# Altium schematic sheet DW1000BreakoutPeripherals.SchDoc(DW1000BreakoutPeripherals)
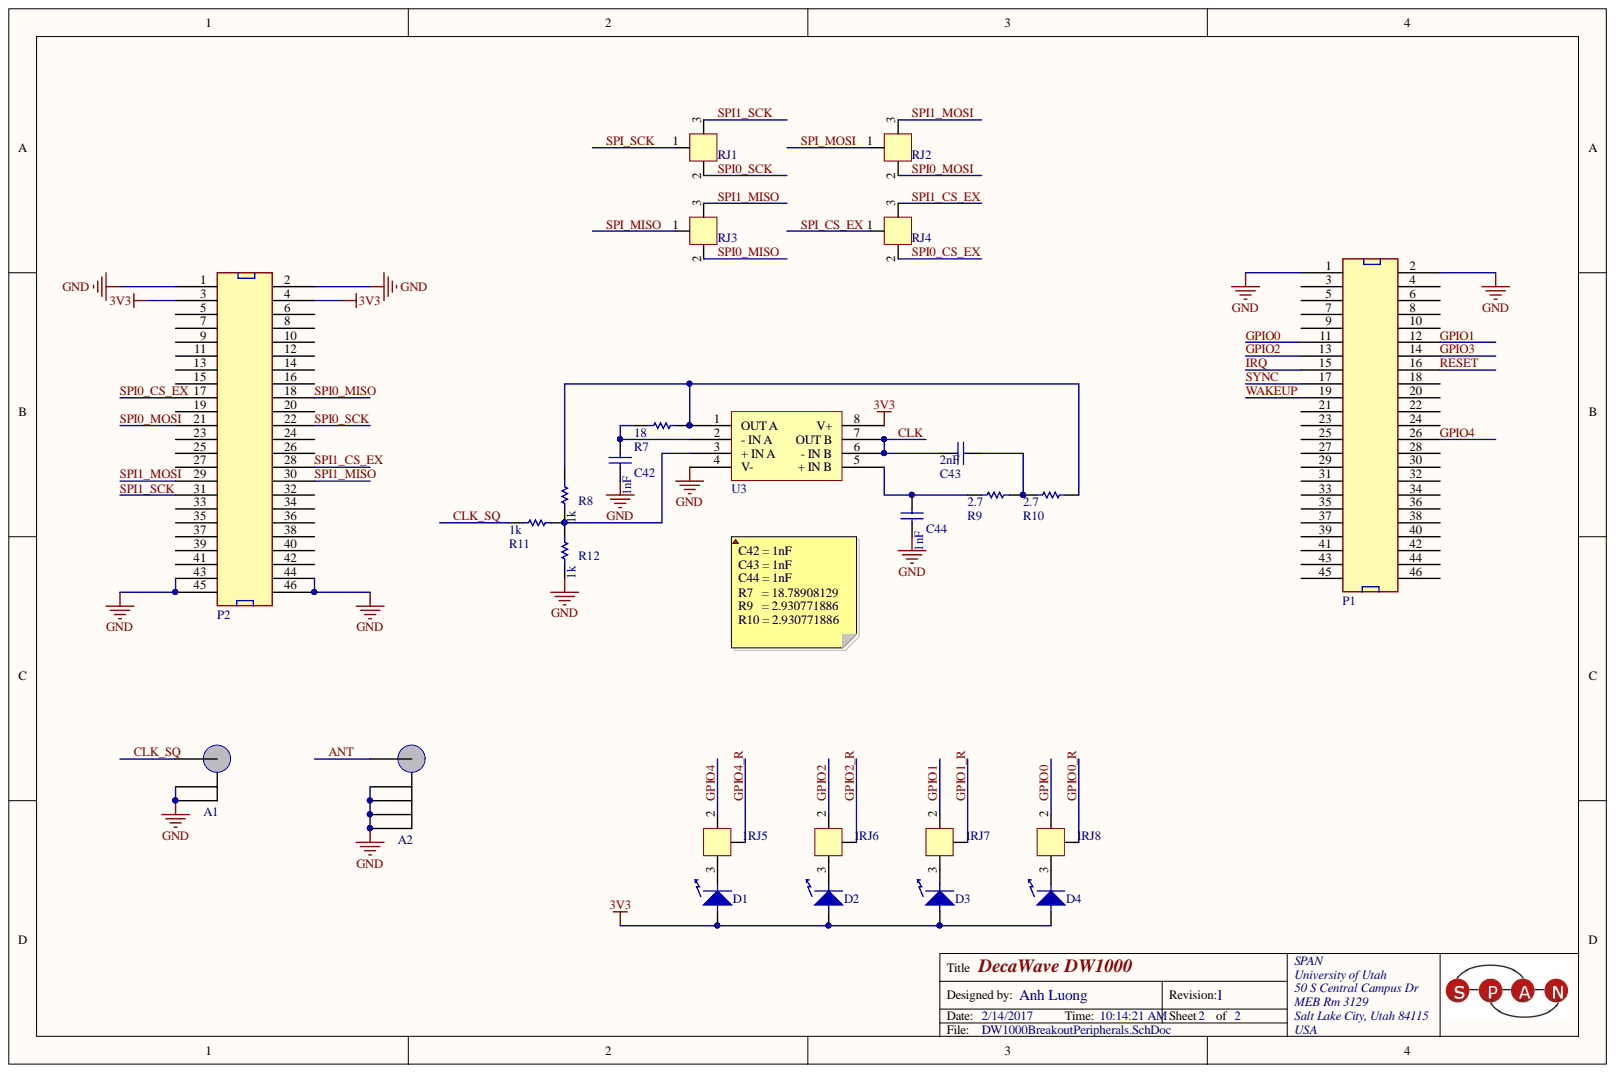
[diagram p0: DW1000BreakoutPeripherals.SchDoc]

## Parts
A1  U.FL-R-SMT(01)  [U.FL-R-SMT-1]  (value not drawn)
A2  CONSMA003.062  [SMA_EDGE_MNT]  (value not drawn)
C42  GRM033R71C102KA01D  [CAPC1608X08N (0603)]  (value not drawn)
C43  GRM1885C1H202JA01D  [CAPC1608X08N (0603)]  (value not drawn)
C44  GRM033R71C102KA01D  [CAPC1608X08N (0603)]  (value not drawn)
D1  LG Q971-KN-1  [CHIPLED0603]  (value not drawn)
D2  LG Q971-KN-1  [CHIPLED0603]  (value not drawn)
D3  LG Q971-KN-1  [CHIPLED0603]  (value not drawn)
D4  LG Q971-KN-1  [CHIPLED0603]  (value not drawn)
P1  PREC023DAAN-RC  [HEADER23X2]  (value not drawn)
P2  PREC023DAAN-RC  [HEADER23X2]  (value not drawn)
R7  MCR03ERTJ180  [RESC1608X06N (0603)]  (value not drawn)
R8  Resistance (Ohms): 1k  [RESC1608X06N (0603)]  (hidden comment: MCR03ERTJ102)
R9  Resistance (Ohms): 2.7  [RESC1608X06N (0603)]  (hidden comment: MCR03ERTJ2R7)
R10  Resistance (Ohms): 2.7  [RESC1608X06N (0603)]  (hidden comment: MCR03ERTJ2R7)
R11  Resistance (Ohms): 1k  [RESC1608X06N (0603)]  (hidden comment: MCR03ERTJ102)
R12  Resistance (Ohms): 1k  [RESC1608X06N (0603)]  (hidden comment: MCR03ERTJ102)
RJ1  R_J  [RJ]
RJ2  R_J  [RJ]
RJ3  R_J  [RJ]
RJ4  R_J  [RJ]
RJ5  R_J  [RJ]
RJ6  R_J  [RJ]
RJ7  R_J  [RJ]
RJ8  R_J  [RJ]
U3  LMV822MM/NOPB  [TSOP65P490X110-8N]  (value not drawn)

## Nets
3V3: D1.1 D2.1 D3.1 D4.1 P2.3 P2.4 U3.8(V+)
GND: A1.2 A1.3 A2.2 A2.3 A2.4 A2.5 C42.1 C44.1 P1.1 P1.2 P2.1 P2.2 P2.43 P2.44 P2.45 P2.46 R12.1 U3.4(V-)
ANT: A2.1
CLK: C43.1 U3.6(-_IN_B) U3.7(OUT_B)
CLK_SQ: A1.1 R11.1
GPIO0: P1.11 RJ8.2
GPIO0_R: RJ8.1
GPIO1: P1.12 RJ7.2
GPIO1_R: RJ7.1
GPIO2: P1.13 RJ6.2
GPIO2_R: RJ6.1
GPIO3: P1.14
GPIO4: P1.26 RJ5.2
GPIO4_R: RJ5.1
IRQ: P1.15
RESET: P1.16
SPI0_CS_EX: P2.17 RJ4.2
SPI0_MISO: P2.18 RJ3.2
SPI0_MOSI: P2.21 RJ2.2
SPI0_SCK: P2.22 RJ1.2
SPI1_CS_EX: P2.28 RJ4.3
SPI1_MISO: P2.30 RJ3.3
SPI1_MOSI: P2.29 RJ2.3
SPI1_SCK: P2.31 RJ1.3
SPI_CS_EX: RJ4.1
SPI_MISO: RJ3.1
SPI_MOSI: RJ2.1
SPI_SCK: RJ1.1
SYNC: P1.17
WAKEUP: P1.19
NetC42_2: C42.2 R7.1 U3.2(-_IN_A)
NetC43_2: C43.2 R9.2 R10.1
NetC44_2: C44.2 R9.1 U3.5(+_IN_B)
NetD1_2: D1.2 RJ5.3
NetD2_2: D2.2 RJ6.3
NetD3_2: D3.2 RJ7.3
NetD4_2: D4.2 RJ8.3
NetR7_2: R7.2 R8.2 R10.2 U3.1(OUT_A)
NetR8_1: R8.1 R11.2 R12.2 U3.3(+_IN_A)

Not connected: P1 3 4 5 6 7 8 9 10 18 20 21 22 23 24 25 27 28 29 30 31 32 33 34 35 36 37 38 39 40 41 42 43 44 45 46; P2 5 6 7 8 9 10 11 12 13 14 15 16 19 20 23 24 25 26 27 32 33 34 35 36 37 38 39 40 41 42

## Library data of the parts
A1 = U.FL-R-SMT(01): CONN UMC JACK STR 50 OHM SMD; Manufacturer: Hirose Electric Co Ltd; Shield Termination: Solder; Impedance: 50 Ohm; Frequency - Max: 6GHz; Dielectric Material: Liquid Crystal Polymer (LCP); Connector Type: Jack, Male Pin; Connector Style: Ultra Miniature Coaxial; Center Contact Plating: Gold; Center Contact Material: Phosphor Bronze; Body Finish: Silver; Voltage Rating: -; Supplier 1: Digi-Key; Stock 1: 22286; RoHS: RoHS Compliant; Pricing 1: 1=1.28, 10=1.122, 25=1.056, 50=1.012, 100=0.968, 250=0.88, 500=0.792, 1000=0.704, 2500=0.638 (USD); Packaging: Bulk; Operating Temperature: -40°C ~ 90°C; Mounting Type: Surface Mount; Manufacturer Part Number: U.FL-R-SMT(01); Library Name: DW1000Breakout.SchLib; Ingress Protection: -; Housing Color: Silver; Features: -; Fastening Type: Snap-On; Contact Termination: Solder; ComponentLink1URL: http://www.hirose.co.jp/cataloge_hp/e32119372.pdf; ComponentLink1Description: http://www.hirose.co.jp/cataloge_hp/e32119372.pdf; Component Kind: Standard; Category: Connectors, Interconnects; Cable Group: -; Body Material: Phosphor Bronze
A2 = CONSMA003.062: CONN SMA JACK 50 OHM EDGE MNT; Manufacturer: Linx Technologies Inc; Shield Termination: Solder; Impedance: 50 Ohm; Dielectric Material: Polytetrafluoroethylene (PTFE); Connector Type: Jack, Female Socket; Center Contact Plating: Gold; Center Contact Material: Beryllium Copper; Body Material: Brass; Body Finish: Nickel; Voltage Rating: -; Supplier 1: Digi-Key; Stock 1: 6857; RoHS: RoHS Compliant; Pricing 1: 1=3.37, 25=3.09, 100=2.81, 500=2.6125 (USD); Packaging: Bulk; Operating Temperature: -65°C ~ 165°C; Mounting Type: Board Edge, End Launch; Manufacturer Part Number: CONSMA003.062; Library Name: DW1000Breakout.SchLib; Ingress Protection: -; Housing Color: Silver; Frequency - Max: -; Features: -; Fastening Type: Threaded; Contact Termination: Solder; Connector Style: SMA; ComponentLink1URL: http://www.linxtechnologies.com/resources/diagrams/consma003.062.pdf; ComponentLink1Description: http://www.linxtechnologies.com/resources/diagrams/consma003.062.pdf; Component Kind: Standard; Category: Connectors, Interconnects; Cable Group: -
C42 C44 = GRM033R71C102KA01D: CAP CER 1000PF 16V 10% X7R 0201; Manufacturer: Murata Electronics North America; Voltage - Rated: 16V; Tolerance: ±10%; Thickness (Max): 0.013" (0.33mm); Temperature Coefficient: X7R; Supplier 1: Digi-Key; Stock 1: 938390; Size / Dimension: 0.024" L x 0.012" W (0.60mm x 0.30mm); RoHS: RoHS Compliant; Ratings: -; Pricing 1: 1=0.1, 10=0.025, 50=0.0134, 100=0.0113, 250=0.00924, 500=0.0079, 1000=0.00615, 5000=0.00513 (USD); Packaging: Cut Tape (CT); Package / Case: 0201 (0603 Metric); Operating Temperature: -55°C ~ 125°C; Mounting Type: Surface Mount, MLCC; Manufacturer Part Number: GRM033R71C102KA01D; Library Name: DW1000Breakout.SchLib; Lead Style: -; Lead Spacing: -; Height - Seated (Max): -; Features: -; ComponentLink1URL: http://search.murata.co.jp/Ceramy/image/img/A01X/G101/ENG/GRM033R71C102KA01-01.pdf; ComponentLink1Description: http://search.murata.co.jp/Ceramy/image/img/A01X/G101/ENG/GRM033R71C102KA01-01.pdf; Component Kind: Standard; Category: Capacitors; Capacitance: 1000pF; Applications: General Purpose
C43 = GRM1885C1H202JA01D: CAP CER 2000PF 50V NP0 0603; Manufacturer: Murata Electronics North America; Tolerance: ±5%; Temperature Coefficient: C0G, NP0; Voltage - Rated: 50V; Thickness (Max): 0.035" (0.90mm); Supplier Part Number 1: 490-1458-1-ND; Supplier 1: Digi-Key; Stock 1: 163868; Size / Dimension: 0.063" L x 0.031" W (1.60mm x 0.80mm); RoHS: RoHS Compliant; Ratings: -; Pricing 1: 1=0.1, 10=0.073, 50=0.0394, 100=0.0333, 250=0.02728, 500=0.02334, 1000=0.01818 (USD); Part Status: Active; Packaging: Cut Tape (CT); Package / Case: 0603 (1608 Metric); Operating Temperature: -55°C ~ 125°C; Mounting Type: Surface Mount, MLCC; Manufacturer Part Number: GRM1885C1H202JA01D; Library Name: DW1000Breakout.SchLib; Lead Style: -; Lead Spacing: -; Height - Seated (Max): -; Features: -; ComponentLink1URL: http://www.murata.com/~/media/webrenewal/support/library/catalog/products/capacitor/mlcc/c02e.ashx; ComponentLink1Description: http://www.murata.com/~/media/webrenewal/support/library/catalog/products/capacitor/mlcc/c02e.ashx; Component Kind: Standard; Category: Capacitors; Capacitance: 2000pF; Applications: General Purpose
D1-D4 = LG Q971-KN-1: LED CHIPLED 570NM GREEN 0603 SMD; Manufacturer: OSRAM Opto Semiconductors Inc; Wavelength - Peak: 572nm; Voltage - Forward (Vf) Typ: 2.2V; Viewing Angle: 160°; Millicandela Rating: 10mcd; Lens Type: Diffused; Lens Style/Size: Rectangle with Flat Top, 1mm x 0.8mm; Current - Test: 20mA; Wavelength - Dominant: 570nm; Supplier 1: Digi-Key; Stock 1: 44000; Size / Dimension: 1.60mm L x 0.80mm W; RoHS: RoHS Compliant; Pricing 1: 4000=0.03632 (USD); Packaging: Tape & Reel (TR); Package / Case: 0603 (1608 Metric); Mounting Type: Surface Mount; Manufacturer Part Number: LG Q971-KN-1; Luminous Flux @ Current - Test: -; Height: 0.80mm; ComponentLink1URL: http://catalog.osram-os.com/catalogue/catalogue.do;jsessionid=DE4D071D0D2758CC117C711BF848053E?act=downloadFile&favOid=0200000600005069000100b6; ComponentLink1Description: http://catalog.osram-os.com/catalogue/catalogue.do;jsessionid=DE4D071D0D2758CC117C711BF848053E?act=downloadFile&favOid=0200000600005069000100b6; Component Kind: Standard; Color: Green; Category: Optoelectronics; Library Name: DWM1000Breakout.SchLib
P1 P2 = PREC023DAAN-RC: CONN HEADER .100" DUAL STR 46POS; Manufacturer: Sullins Connector Solutions; Row Spacing: 0.100" (2.54mm); Number of Positions Loaded: All; Number of Positions: 46; Connector Type: Header, Unshrouded; Termination: Solder; Supplier 1: Digi-Key; Stock 1: 0; RoHS: RoHS Compliant; Pricing 1: 1=0.79, 10=0.706, 100=0.5813, 500=0.45952, 1000=0.39447, 5000=0.35987, 10000=0.34603 (USD); Pitch: 0.100" (2.54mm); Packaging: Bulk; Number of Rows: 2; Mounting Type: Through Hole; Manufacturer Part Number: PREC023DAAN-RC; Features: -; Fastening Type: -; Contact Type: Male Pin; Contact Mating Length: 0.230" (5.84mm); Contact Finish Thickness: -; Contact Finish: Tin; ComponentLink1URL: http://media.digikey.com/PDF/Data%20Sheets/Sullins%20PDFs/xRxCzzzDxxN-RC_11636-B.pdf; ComponentLink1Description: http://media.digikey.com/PDF/Data%20Sheets/Sullins%20PDFs/xRxCzzzDxxN-RC_11636-B.pdf; Component Kind: Standard; Color: Black; Category: Connectors, Interconnects; Library Name: Chronos.SchLib
R7 = MCR03ERTJ180: RES SMD 18 OHM 5% 1/10W 0603; Manufacturer: Rohm Semiconductor; Temperature Coefficient: ±200ppm/°C; Resistance (Ohms): 18; Power (Watts): 0.1W, 1/10W; Tolerance: ±5%; Supplier Part Number 1: RHM18CGCT-ND; Supplier Device Package: 0603; Supplier 1: Digi-Key; Stock 1: 8252; Size / Dimension: 0.063" L x 0.031" W (1.60mm x 0.80mm); RoHS: RoHS Compliant; Pricing 1: 1=0.1, 10=0.017, 25=0.012, 50=0.0092, 100=0.0068, 250=0.00524, 500=0.00418, 1000=0.00307 (USD); Part Status: Active; Packaging: Cut Tape (CT); Package / Case: 0603 (1608 Metric); Operating Temperature: -55°C ~ 155°C; Number of Terminations: 2; Manufacturer Part Number: MCR03ERTJ180; Library Name: DW1000Breakout.SchLib; Height - Seated (Max): 0.022" (0.55mm); Features: -; Failure Rate: -; Composition: Thick Film; ComponentLink1URL: http://rohmfs.rohm.com/en/products/databook/datasheet/passive/resistor/chip_resistor/mcr_g.pdf; ComponentLink1Description: http://rohmfs.rohm.com/en/products/databook/datasheet/passive/resistor/chip_resistor/mcr_g.pdf; Component Kind: Standard; Category: Resistors
R8 R11 R12 = MCR03ERTJ102: RES 1K OHM 1/10W 5% 0603 SMD; Manufacturer: Rohm Semiconductor; Temperature Coefficient: ±200ppm/°C; Power (Watts): 0.1W, 1/10W; Tolerance: ±5%; Supplier Device Package: 0603 (1608 Metric); Supplier 1: Digi-Key; Stock 1: 72962; Size / Dimension: 0.063" L x 0.031" W (1.60mm x 0.80mm); RoHS: RoHS Compliant; Pricing 1: 1=0.1, 10=0.01, 25=0.0072, 100=0.0042, 250=0.00316, 500=0.00254, 1000=0.00187 (USD); Packaging: Cut Tape (CT); Package / Case: 0603 (1608 Metric); Operating Temperature: -55°C ~ 155°C; Number of Terminations: 2; Manufacturer Part Number: MCR03ERTJ102; Library Name: DW1000Breakout.SchLib; Height: 0.022" (0.55mm); Features: -; Composition: Thick Film; ComponentLink1URL: http://rohmfs.rohm.com/en/products/databook/datasheet/passive/resistor/chip_resistor/mcr_g.pdf; ComponentLink1Description: http://rohmfs.rohm.com/en/products/databook/datasheet/passive/resistor/chip_resistor/mcr_g.pdf; Component Kind: Standard; Category: Resistors
R9 R10 = MCR03ERTJ2R7: RES SMD 2.7 OHM 5% 1/10W 0603; Manufacturer: Rohm Semiconductor; Temperature Coefficient: ±400ppm/°C; Power (Watts): 0.1W, 1/10W; Tolerance: ±5%; Supplier Part Number 1: RHM2.7CGCT-ND; Supplier Device Package: 0603; Supplier 1: Digi-Key; Stock 1: 12955; Size / Dimension: 0.063" L x 0.031" W (1.60mm x 0.80mm); RoHS: RoHS Compliant; Pricing 1: 1=0.1, 10=0.017, 25=0.012, 50=0.0092, 100=0.0068, 250=0.00524, 500=0.00418, 1000=0.00307 (USD); Part Status: Active; Packaging: Cut Tape (CT); Package / Case: 0603 (1608 Metric); Operating Temperature: -55°C ~ 155°C; Number of Terminations: 2; Manufacturer Part Number: MCR03ERTJ2R7; Library Name: DW1000Breakout.SchLib; Height - Seated (Max): 0.022" (0.55mm); Features: -; Failure Rate: -; Composition: Thick Film; ComponentLink1URL: http://rohmfs.rohm.com/en/products/databook/datasheet/passive/resistor/chip_resistor/mcr_g.pdf; ComponentLink1Description: http://rohmfs.rohm.com/en/products/databook/datasheet/passive/resistor/chip_resistor/mcr_g.pdf; Component Kind: Standard; Category: Resistors
RJ1-RJ8 = R_J: Resistor Jumper; Value: DNP; Package / Case: 0603 (1608 Metric); Mounting Type: Surface Mount, MLCC; Component Kind: Standard; Applications: General Purpose; Library Name: DWM1000Breakout.SchLib
U3 = LMV822MM/NOPB: Operational Amplifiers - Op Amps Low Volt Dual Op Amp; Manufacturer: Texas Instruments; Vos - Input Offset Voltage: 3.5 mV; Voltage Gain dB: 105 dB; Supply Voltage - Min: 2.5 V; Supply Voltage - Max: 5.5 V; Supply Type: Single; SR - Slew Rate: 2 V/us; Shutdown: No Shutdown; PSRR - Power Supply Rejection Ratio: 75 dB; Output Type: Rail-to-Rail; Output Current per Channel: 45 mA; Operating Supply Voltage: 2.7 V, 5 V; Operating Supply Current: 250 uA; Number of Channels: 2 Channel; Ios - Input Offset Current: 50 nA; In - Input Noise Current Density: 0.25 pA/sqrt Hz; Ib - Input Bias Current: 100 nA; GBP - Gain Bandwidth Product: 5.6 MHz; en - Input Voltage Noise Density: 24 nV/sqrt Hz at 5 V; CMRR - Common Mode Rejection Ratio: 72 dB; Amplifier Type: General Purpose; Width: 3 mm; Supplier Part Number 1: 926-LMV822MM/NOPB; Supplier 1: Mouser; Stock 1: 1996; Standard Pack Qty: 1000; Series: LMV822-N; RoHS - Mouser: Y; RoHS: RoHS Compliant; Reeling: True; Product: Operational Amplifiers; Pricing 1: 1=1.40, 10=1.26, 25=1.16, 50=1.04, 100=0.984, 250=0.920, 500=0.813, 1000=0.535 (USD); Packaging: Reel; Package / Case: MSOP-8; Mounting Style: SMD/SMT; Minimum Order Quantity: 1; Minimum Operating Temperature: - 40 C; Maximum Operating Temperature: + 85 C; Manufacturer Part Number: LMV822MM/NOPB; Library Name: DW1000Breakout.SchLib; Length: 3 mm; Input Type: Rail-to-Rail; Height: 0.86 mm; Component Kind: Standard; Category: Operational Amplifiers - Op Amps; Brand: Texas Instruments
